# Altium schematic sheet Main.SchDoc(Main)
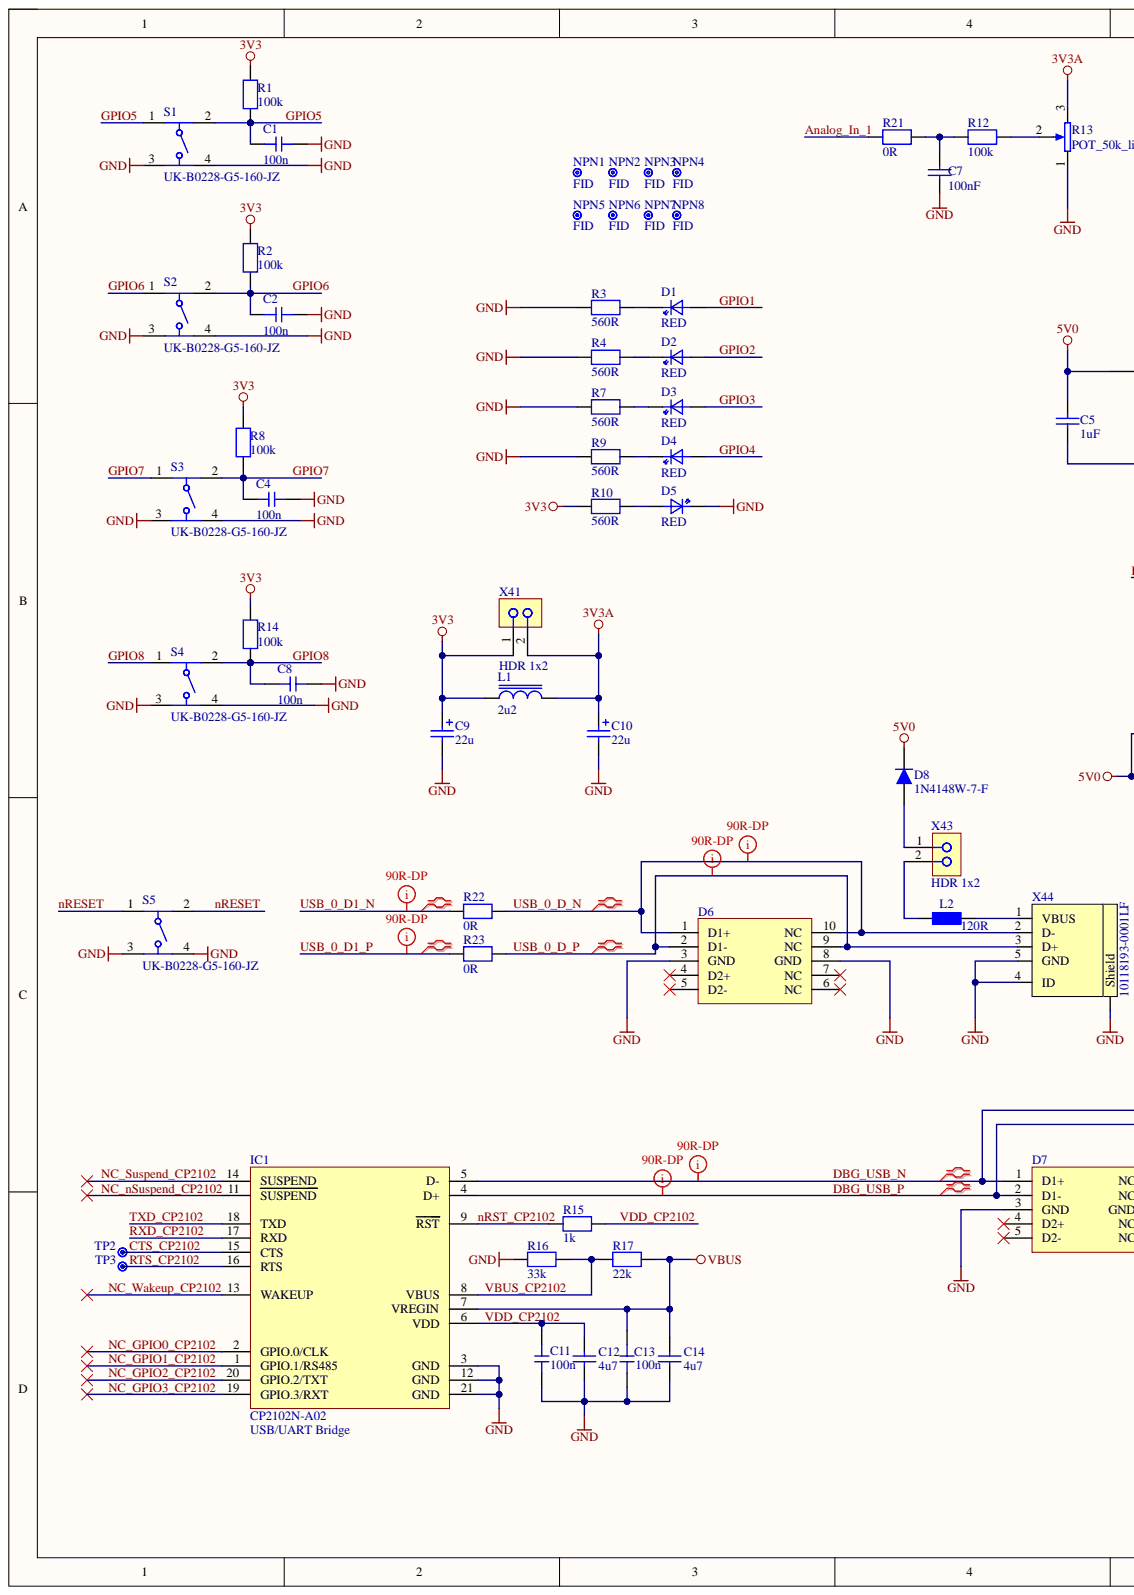
[diagram p0: Main.SchDoc, left]
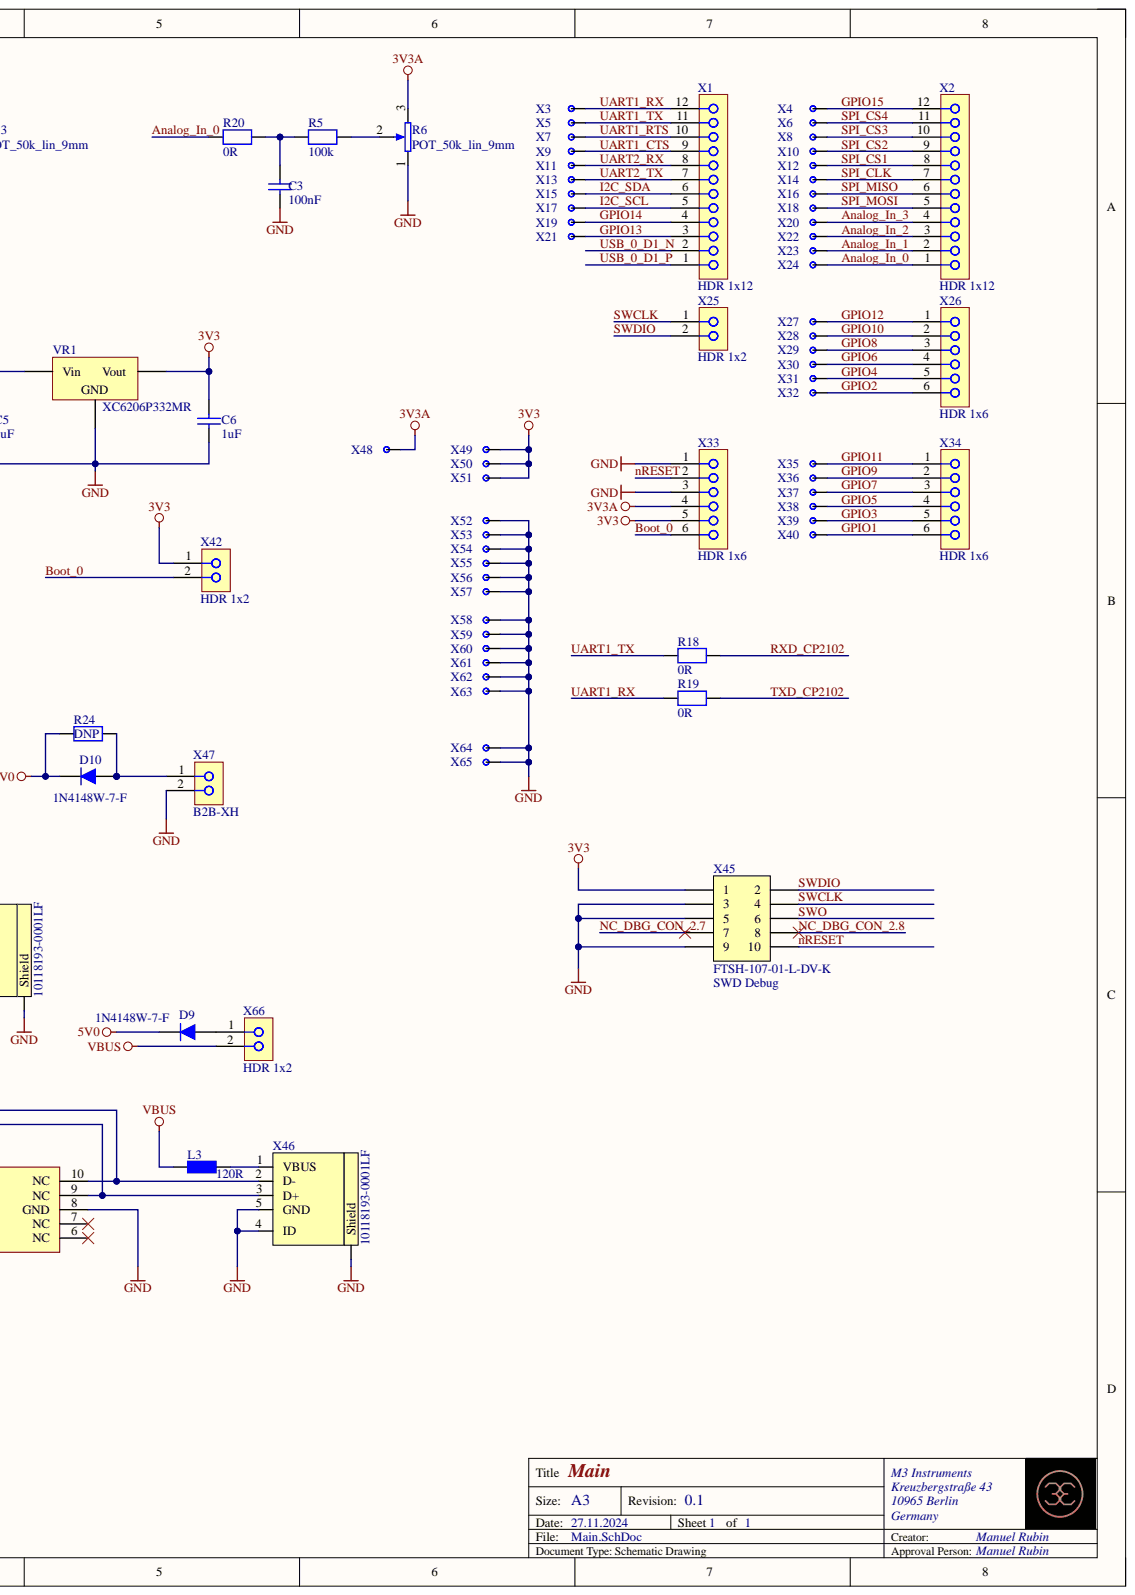
[diagram p1: Main.SchDoc, right]

## Parts
C1  100n  [CAPC1608X95N]
C2  100n  [CAPC1608X95N]
C3  100nF  [CAPC1608X95N]
C4  100n  [CAPC1608X95N]
C5  1uF  [CAPC2012X145N]
C6  1uF  [CAPC2012X145N]
C7  100nF  [CAPC1608X95N]
C8  100n  [CAPC1608X95N]
C9  22u  [CAPAE4643X54M]
C10  22u  [CAPAE4643X54M]
C11  100n  [CAPC1608X95N]
C12  4u7  [CAPC1608X95N]
C13  100n  [CAPC1608X95N]
C14  4u7  [CAPC1608X95N]
D1  RED  [WL-SMCW_0805]
D2  RED  [WL-SMCW_0805]
D3  RED  [WL-SMCW_0805]
D4  RED  [WL-SMCW_0805]
D5  RED  [WL-SMCW_0805]
D6  (no value)  [DQA R-PUSON-N10]
D7  (no value)  [DQA R-PUSON-N10]
D8  1N4148W-7-F  [SOD-123]
D9  1N4148W-7-F  [SOD-123]
D10  1N4148W-7-F  [SOD-123]
IC1  CP2102N-A02  DevNote: USB/UART Bridge  [SILABS_CP2102N-A02-GQFN20R]
L1  2u2  [INDP5050X39N]
L2  120R  [INDC1608X95AN]
L3  120R  [INDC1608X95AN]
NPN1  FID  [Fiducial_1mm]
NPN2  FID  [Fiducial_1mm]
NPN3  FID  [Fiducial_1mm]
NPN4  FID  [Fiducial_1mm]
NPN5  FID  [Fiducial_1mm]
NPN6  FID  [Fiducial_1mm]
NPN7  FID  [Fiducial_1mm]
NPN8  FID  [Fiducial_1mm]
R1  100k  [RESC2012X70N]
R2  100k  [RESC2012X70N]
R3  560R  [RESC1608X55N]
R4  560R  [RESC1608X55N]
R5  100k  [RESC2012X70N]
R6  POT_50k_lin_9mm  [PTV09]  (value not drawn)
R7  560R  [RESC1608X55N]
R8  100k  [RESC2012X70N]
R9  560R  [RESC1608X55N]
R10  560R  [RESC1608X55N]
R12  100k  [RESC2012X70N]
R13  POT_50k_lin_9mm  [PTV09]  (value not drawn)
R14  100k  [RESC2012X70N]
R15  1k  [RESC1608X55AN]
R16  33k  [RESC1608X55AN]
R17  22k  [RESC1608X55AN]
R18  0R  [RESC1608X55N]
R19  0R  [RESC1608X55N]
R20  0R  [RESC1608X55N]
R21  0R  [RESC1608X55N]
R22  0R  [RESC1608X55N]
R23  0R  [RESC1608X55N]
R24  DNP  [RESC2012X70N]  (no BOM)
S1  UK-B0228-G5-160-JZ  [UK-B0228-G5-160-JZ]
S2  UK-B0228-G5-160-JZ  [UK-B0228-G5-160-JZ]
S3  UK-B0228-G5-160-JZ  [UK-B0228-G5-160-JZ]
S4  UK-B0228-G5-160-JZ  [UK-B0228-G5-160-JZ]
S5  UK-B0228-G5-160-JZ  [UK-B0228-G5-160-JZ]
TP2  TP 0.7mm  [TP_0.7mm]  (value not drawn)  (no BOM)
TP3  TP 0.7mm  [TP_0.7mm]  (value not drawn)  (no BOM)
VR1  XC6206P332MR  [SOT95P280X135-3N_123]
X1  HDR 1x12  [61301211821_NO]
X2  HDR 1x12  [61301211821_NO]
X3  PAD  [PAD_1.1_1.6]  (value not drawn)
X4  PAD  [PAD_1.1_1.6]  (value not drawn)
X5  PAD  [PAD_1.1_1.6]  (value not drawn)
X6  PAD  [PAD_1.1_1.6]  (value not drawn)
X7  PAD  [PAD_1.1_1.6]  (value not drawn)
X8  PAD  [PAD_1.1_1.6]  (value not drawn)
X9  PAD  [PAD_1.1_1.6]  (value not drawn)
X10  PAD  [PAD_1.1_1.6]  (value not drawn)
X11  PAD  [PAD_1.1_1.6]  (value not drawn)
X12  PAD  [PAD_1.1_1.6]  (value not drawn)
X13  PAD  [PAD_1.1_1.6]  (value not drawn)
X14  PAD  [PAD_1.1_1.6]  (value not drawn)
X15  PAD  [PAD_1.1_1.6]  (value not drawn)
X16  PAD  [PAD_1.1_1.6]  (value not drawn)
X17  PAD  [PAD_1.1_1.6]  (value not drawn)
X18  PAD  [PAD_1.1_1.6]  (value not drawn)
X19  PAD  [PAD_1.1_1.6]  (value not drawn)
X20  PAD  [PAD_1.1_1.6]  (value not drawn)
X21  PAD  [PAD_1.1_1.6]  (value not drawn)
X22  PAD  [PAD_1.1_1.6]  (value not drawn)
X23  PAD  [PAD_1.1_1.6]  (value not drawn)
X24  PAD  [PAD_1.1_1.6]  (value not drawn)
X25  HDR 1x2  [61300211821_NO]
X26  HDR 1x6  [61300611821_NO]
X27  PAD  [PAD_1.1_1.6]  (value not drawn)
X28  PAD  [PAD_1.1_1.6]  (value not drawn)
X29  PAD  [PAD_1.1_1.6]  (value not drawn)
X30  PAD  [PAD_1.1_1.6]  (value not drawn)
X31  PAD  [PAD_1.1_1.6]  (value not drawn)
X32  PAD  [PAD_1.1_1.6]  (value not drawn)
X33  HDR 1x6  [61300611821_NO]
X34  HDR 1x6  [61300611821_NO]
X35  PAD  [PAD_1.1_1.6]  (value not drawn)
X36  PAD  [PAD_1.1_1.6]  (value not drawn)
X37  PAD  [PAD_1.1_1.6]  (value not drawn)
X38  PAD  [PAD_1.1_1.6]  (value not drawn)
X39  PAD  [PAD_1.1_1.6]  (value not drawn)
X40  PAD  [PAD_1.1_1.6]  (value not drawn)
X41  HDR 1x2  [CON_HEADER2.54_1x2W]
X42  HDR 1x2  [CON_HEADER2.54_1x2W]
X43  HDR 1x2  [CON_HEADER2.54_1x2W]
X44  10118193-0001LF  [USB-Micro-B-RA-SMD-PiP]
X45  FTSH-107-01-L-DV-K  DevNote: SWD Debug  [HDR_2x5-SMD-1.27mm-K]
X46  10118193-0001LF  [USB-Micro-B-RA-SMD-PiP]
X47  B2B-XH  [JST_B2B-XH-A_(LF)(SN)]
X48  PAD  [PAD_1.1_1.6]  (value not drawn)
X49  PAD  [PAD_1.1_1.6]  (value not drawn)
X50  PAD  [PAD_1.1_1.6]  (value not drawn)
X51  PAD  [PAD_1.1_1.6]  (value not drawn)
X52  PAD  [PAD_1.1_1.6]  (value not drawn)
X53  PAD  [PAD_1.1_1.6]  (value not drawn)
X54  PAD  [PAD_1.1_1.6]  (value not drawn)
X55  PAD  [PAD_1.1_1.6]  (value not drawn)
X56  PAD  [PAD_1.1_1.6]  (value not drawn)
X57  PAD  [PAD_1.1_1.6]  (value not drawn)
X58  PAD  [PAD_1.1_1.6]  (value not drawn)
X59  PAD  [PAD_1.1_1.6]  (value not drawn)
X60  PAD  [PAD_1.1_1.6]  (value not drawn)
X61  PAD  [PAD_1.1_1.6]  (value not drawn)
X62  PAD  [PAD_1.1_1.6]  (value not drawn)
X63  PAD  [PAD_1.1_1.6]  (value not drawn)
X64  PAD  [PAD_1.1_1.6]  (value not drawn)
X65  PAD  [PAD_1.1_1.6]  (value not drawn)
X66  HDR 1x2  [CON_HEADER2.54_1x2W]

## Nets
3V3: C6.1 C9.1 L1.1 R1.2 R2.2 R8.2 R10.1 R14.2 VR1.2 X33.5 X41.1 X42.1 X45.1 X49.1 X50.1 X51.1
3V3A: C10.1 L1.2 R6.3 R13.3 X33.4 X41.2 X48.1
5V0: C5.1 D8.2 D9.2 D10.2 R24.1 VR1.3
GND: C1.2 C2.2 C3.2 C4.2 C5.2 C6.2 C7.2 C8.2 C9.2 C10.2 C11.1 C12.1 C13.1 C14.1 D5.K D6.3(GND) D6.8(GND) D7.3(GND) D7.8(GND) IC1.3(GND) IC1.12(GND) IC1.21(GND) R3.1 R4.1 R6.1 R7.1 R9.1 R13.1 R16.2 S1.3 S1.4 S2.3 S2.4 S3.3 S3.4 S4.3 S4.4 S5.3 S5.4 VR1.1 X33.1 X33.3 X44.4(ID) X44.5(GND) X44.6(Shield) X45.3 X45.5 X45.9 X46.4(ID) X46.5(GND) X46.6(Shield) X47.2 X52.1 X53.1 X54.1 X55.1 X56.1 X57.1 X58.1 X59.1 X60.1 X61.1 X62.1 X63.1 X64.1 X65.1
VBUS: C13.2 C14.2 IC1.7(VREGIN) L3.1 R17.1 X66.2
Analog_In_0: R20.2 X2.1 X24.1
Analog_In_1: R21.2 X2.2 X23.1
Analog_In_2: X2.3 X22.1
Analog_In_3: X2.4 X20.1
Boot_0: X33.6 X42.2
CTS_CP2102: IC1.15(CTS) TP2.1
DBG_USB_N: D7.1(D1+) D7.10(NC) IC1.5(D-) X46.2(D-)
DBG_USB_P: D7.2(D1-) D7.9(NC) IC1.4(D+) X46.3(D+)
GPIO1: D1.A X34.6 X40.1
GPIO2: D2.A X26.6 X32.1
GPIO3: D3.A X34.5 X39.1
GPIO4: D4.A X26.5 X31.1
GPIO5: C1.1 R1.1 S1.1 S1.2 X34.4 X38.1
GPIO6: C2.1 R2.1 S2.1 S2.2 X26.4 X30.1
GPIO7: C4.1 R8.1 S3.1 S3.2 X34.3 X37.1
GPIO8: C8.1 R14.1 S4.1 S4.2 X26.3 X29.1
GPIO9: X34.2 X36.1
GPIO10: X26.2 X28.1
GPIO11: X34.1 X35.1
GPIO12: X26.1 X27.1
GPIO13: X1.3 X21.1
GPIO14: X1.4 X19.1
GPIO15: X2.12 X4.1
I2C_SCL: X1.5 X17.1
I2C_SDA: X1.6 X15.1
NC_DBG_CON_2.7: X45.7
NC_DBG_CON_2.8: X45.8
NC_GPIO0_CP2102: IC1.2(GPIO.0/CLK)
NC_GPIO1_CP2102: IC1.1(GPIO.1/RS485)
NC_GPIO2_CP2102: IC1.20(GPIO.2/TXT)
NC_GPIO3_CP2102: IC1.19(GPIO.3/RXT)
NC_nSuspend_CP2102: IC1.11(SUSPEND)
NC_Suspend_CP2102: IC1.14(SUSPEND)
NC_Wakeup_CP2102: IC1.13(WAKEUP)
nRESET: S5.1 S5.2 X33.2 X45.10
nRST_CP2102: IC1.9(RST) R15.2
RTS_CP2102: IC1.16(RTS) TP3.1
RXD_CP2102: IC1.17(RXD) R18.1
SPI_CLK: X2.7 X14.1
SPI_CS1: X2.8 X12.1
SPI_CS2: X2.9 X10.1
SPI_CS3: X2.10 X8.1
SPI_CS4: X2.11 X6.1
SPI_MISO: X2.6 X16.1
SPI_MOSI: X2.5 X18.1
SWCLK: X25.1 X45.4
SWDIO: X25.2 X45.2
SWO: X45.6
TXD_CP2102: IC1.18(TXD) R19.1
UART1_CTS: X1.9 X9.1
UART1_RTS: X1.10 X7.1
UART1_RX: R19.2 X1.12 X3.1
UART1_TX: R18.2 X1.11 X5.1
UART2_RX: X1.8 X11.1
UART2_TX: X1.7 X13.1
USB_0_D1_N: R22.2 X1.2
USB_0_D1_P: R23.2 X1.1
USB_0_D_N: D6.1(D1+) D6.10(NC) R22.1 X44.2(D-)
USB_0_D_P: D6.2(D1-) D6.9(NC) R23.1 X44.3(D+)
VBUS_CP2102: IC1.8(VBUS) R16.1 R17.2
VDD_CP2102: C11.2 C12.2 IC1.6(VDD) R15.1
NetC3_1: C3.1 R5.1 R20.1
NetC7_1: C7.1 R12.1 R21.1
NetD1_K: D1.K R3.2
NetD2_K: D2.K R4.2
NetD3_K: D3.K R7.2
NetD4_K: D4.K R9.2
NetD5_A: D5.A R10.2
NetD8_1: D8.1 X43.1
NetD9_1: D9.1 X66.1
NetD10_1: D10.1 R24.2 X47.1
NetL2_1: L2.1 X43.2
NetL2_2: L2.2 X44.1(VBUS)
NetL3_2: L3.2 X46.1(VBUS)
NetR5_2: R5.2 R6.2
NetR12_2: R12.2 R13.2

Not connected: D6 4(D2+) 5(D2-) 6(NC) 7(NC); D7 4(D2+) 5(D2-) 6(NC) 7(NC)

## Library data of the parts
C1 C2 C4 C8 C11 C13 = C_100n_50V_0603: 100n_50V; Manufacturer: AVX; Manufacturer Part Number: 06031C104KAT2A; Alternatives allowed: YES; Notes: X7R, 0603; Tolerance: +-10%; Value: 100nF; Voltage Rating: 50V; Component Kind: Standard; JLC: C14663; Library Name: Raindancer.SCHLIB
C3 C7 = CAP_100nF_0603: Multilayer Ceramic Capacitors MLCC - SMD/SMT, 100nF; Manufacturer: YAGEO; Manufacturer Part Number: CC0603KRX7R9BB104; Alternatives allowed: yes; Tolerance: 10%; Voltage Rating: 50VDC; Component Kind: Standard; JLC: C14663; Library Name: Caps.SchLib
C5 C6 = CAP_1uF_0805: Multilayer Ceramic Capacitors MLCC - SMD/SMT, 1uF; Manufacturer: Samsung Electro-Mechanics; Manufacturer Part Number: CL21B105KBFNNNE; Alternatives allowed: yes; Tolerance: 10%; Voltage Rating: 50V; Component Kind: Standard; JLC: C28323; Library Name: Caps.SchLib
C9 C10 = CAP_22u: Aluminium Electrolytic Capacitors - SMD 22UF 16V HA SMD; Manufacturer: Panasonic; Manufacturer Part Number: EEE-HAC220WAR; Alternatives allowed: NO; Tolerance: 20%; Component Kind: Standard; Library Name: Caps.SchLib; Voltage Rating: 16V
C12 C14 = CAP_4u7_0603: 16V 4.7uF X5R ±10% 0603 Multilayer Ceramic Capacitors MLCC - SMD/SMT ROHS; Manufacturer: Murata Electronics; Manufacturer Part Number: GRM188C81C475KE11D; Alternatives allowed: yes; Voltage Rating: 16VDC; Component Kind: Standard; JLC: C19666; Library Name: Caps.SchLib; Tolerance: 10%
D1 = LED_0805_RED_AK: Standard LEDs - SMD WL-SMCW SMDMono TpVw Waterclr 0805 Red; Manufacturer: Wurth Elektronik; Manufacturer Part Number: 150080RS75000; Alternatives allowed: YES; DevNote: LED1; Voltage Rating: 2.0; Component Kind: Standard; JLC: C84256; Library Name: Diodes.SchLib
D2 = LED_0805_RED_AK: Standard LEDs - SMD WL-SMCW SMDMono TpVw Waterclr 0805 Red; Manufacturer: Wurth Elektronik; Manufacturer Part Number: 150080RS75000; Alternatives allowed: YES; DevNote: LED2; Voltage Rating: 2.0; Component Kind: Standard; JLC: C84256; Library Name: Diodes.SchLib
D3 = LED_0805_RED_AK: Standard LEDs - SMD WL-SMCW SMDMono TpVw Waterclr 0805 Red; Manufacturer: Wurth Elektronik; Manufacturer Part Number: 150080RS75000; Alternatives allowed: YES; DevNote: LED3; Voltage Rating: 2.0; Component Kind: Standard; JLC: C84256; Library Name: Diodes.SchLib
D4 = LED_0805_RED_AK: Standard LEDs - SMD WL-SMCW SMDMono TpVw Waterclr 0805 Red; Manufacturer: Wurth Elektronik; Manufacturer Part Number: 150080RS75000; Alternatives allowed: YES; DevNote: LED4; Voltage Rating: 2.0; Component Kind: Standard; JLC: C84256; Library Name: Diodes.SchLib
D5 = LED_0805_RED_AK: Standard LEDs - SMD WL-SMCW SMDMono TpVw Waterclr 0805 Red; Manufacturer: Wurth Elektronik; Manufacturer Part Number: 150080RS75000; Alternatives allowed: YES; Voltage Rating: 2.0; Component Kind: Standard; JLC: C84256; Library Name: Diodes.SchLib
D6 D7 = TPD4EUSB30DQAR: 2-, 4-Channel ESD Protection for Super-Speed USB 3.0 Interface; Manufacturer: Texas Instruments; Alternatives allowed: NO; Component Kind: Standard; Library Name: Diodes.SchLib; Manufacturer Part Number: TPD4EUSB30DQAR
D8-D10 = 1N4148W-7-F: Diodes - General Purpose, Power, Switching SURFACE MOUNT FAST SWITCHING DIODE 2A; Manufacturer: Diodes Incorporated; Component Kind: Standard; JLC: C81598; Library Name: Diodes.SchLib; Manufacturer Part Number: 1N4148W-7-F; Package: SOD-123
IC1 = IC_CP2102N-A02-QFN20: USB Interface IC USBXpress - USB to UART Bridge QFN28; Manufacturer: Silicon Labs; Manufacturer Part Number: CP2102N-A02-GQFN20R; Alternatives allowed: NO; Component Kind: Standard; ComponentLink1Description: Datasheet; ComponentLink2Description: Supplier link; JLC: C969913; Library Name: Raindancer.SCHLIB
L1 = IND_2u2_3.8A: Fixed Inductors 2.2uH 3.8A; Manufacturer: Bourns; Manufacturer Part Number: SRN5040TA-2R2M; Alternatives allowed: yes; Tolerance: 10%; Voltage Rating: 50V; Component Kind: Standard; JLC: N/A; Library Name: Inductors.SchLib
L2 L3 = FB_600R_0603: Chip Bead Inductors for Power Lines; Manufacturer: Taiyo Yuden; Manufacturer Part Number: FBMH1608HL121-T; Alternatives allowed: YES; Notes: 0603, 1,2A; Component Kind: Standard; Library Name: Inductors.SchLib; Value: 120R
NPN1-NPN8 = Fiducial_1mm: Component Kind: Standard; Library Name: MISC.SchLib
R1 R2 R5 R8 R12 R14 = RES_100k_0805: Thick Film Resistors - SMD 1/8watt 100Kohms 1% 100ppm; Manufacturer: Vishay / Dale; Manufacturer Part Number: CRCW0805100KFKEA; Alternatives allowed: YES; Voltage Rating: 150V; Component Kind: Standard; JLC: C17407; Library Name: Resistors.SchLib; Tolerance: 1%
R3 R4 R7 R9 R10 = RES_560R_0603: Thick Film Resistors - SMD 560 Ohms 125 mW 0603; Manufacturer: ROHM Semicon; Manufacturer Part Number: MCR03ERTF5600; Alternatives allowed: YES; Tolerance: 1%; Voltage Rating: 150V; Component Kind: Standard; JLC: C224731; Library Name: Resistors.SchLib
R6 R13 = POT_50k_lin_9mm: PTV09A-4020F-A203 50k, 20mm; Manufacturer: Bourns; Manufacturer Part Number: PTV09A-5020F-A503; Tolerance: 20%; Component Kind: Standard; Library Name: Resistors.SchLib
R15 = RES_1k_0603: Thick Film Resistors - SMD 1 kOhms 100mW 0603 1%; Manufacturer: Yageo; Manufacturer Part Number: RC0603FR-071KL; Alternatives allowed: YES; Voltage Rating: 75V; Component Kind: Standard; JLC: C21190; Tolerance: 1%; Library Name: Raindancer.SCHLIB
R16 = RES_33k_0603: Thick Film Resistors - SMD 33 kOhms 100 mW 0603 5%; Manufacturer: YAGEO; Manufacturer Part Number: RC0603JR-0733KL; Alternatives allowed: YES; Tolerance: 1%; Voltage Rating: 50V; Component Kind: Standard; JLC: C4216; Library Name: Raindancer.SCHLIB
R17 = RES_22k_0603: Thick Film Resistors - SMD 22kOhms 1/10W 0603 1%; Manufacturer: YAGEO; Manufacturer Part Number: RC0603FR-7W22KL; Alternatives allowed: YES; Voltage Rating: 75V; Component Kind: Standard; JLC: C31850; Tolerance: 1%; Library Name: Raindancer.SCHLIB
R18-R23 = RES_0R_0603: Thick Film Resistors - SMD 1/10watt ZEROohm Jumper; Manufacturer: Vishay; Manufacturer Part Number: CRCW06030000Z0EA; Alternatives allowed: YES; Tolerance: 1%; Voltage Rating: 75V; Component Kind: Standard; JLC: C21189; Library Name: Resistors.SchLib
R24 = RES_DNF_0805: Resistor SMD 0805 - not populated; Library Name: Resistors.SchLib; Notes: Not populated
S1 = SW_UK-B0228-G5-160-JZ: No NO Gull Wing 50mA 6mm 100MΩ 100000 12V 160gf@±50gf -20℃~+70℃ 6mm 5mm Black Round Button Brick nogging SPST SMD Tactile Switches ROHS; Manufacturer: USAKRO; DevNote: BTN1; Component Kind: Standard; JLC: C620316; Library Name: Switches.SchLib; Manufacturer Part Number: UK-B0228-G5-160-JZ
S2 = SW_UK-B0228-G5-160-JZ: No NO Gull Wing 50mA 6mm 100MΩ 100000 12V 160gf@±50gf -20℃~+70℃ 6mm 5mm Black Round Button Brick nogging SPST SMD Tactile Switches ROHS; Manufacturer: USAKRO; DevNote: BTN2; Component Kind: Standard; JLC: C620316; Library Name: Switches.SchLib; Manufacturer Part Number: UK-B0228-G5-160-JZ
S3 = SW_UK-B0228-G5-160-JZ: No NO Gull Wing 50mA 6mm 100MΩ 100000 12V 160gf@±50gf -20℃~+70℃ 6mm 5mm Black Round Button Brick nogging SPST SMD Tactile Switches ROHS; Manufacturer: USAKRO; DevNote: BTN3; Component Kind: Standard; JLC: C620316; Library Name: Switches.SchLib; Manufacturer Part Number: UK-B0228-G5-160-JZ
S4 = SW_UK-B0228-G5-160-JZ: No NO Gull Wing 50mA 6mm 100MΩ 100000 12V 160gf@±50gf -20℃~+70℃ 6mm 5mm Black Round Button Brick nogging SPST SMD Tactile Switches ROHS; Manufacturer: USAKRO; DevNote: BTN4; Component Kind: Standard; JLC: C620316; Library Name: Switches.SchLib; Manufacturer Part Number: UK-B0228-G5-160-JZ
S5 = SW_UK-B0228-G5-160-JZ: No NO Gull Wing 50mA 6mm 100MΩ 100000 12V 160gf@±50gf -20℃~+70℃ 6mm 5mm Black Round Button Brick nogging SPST SMD Tactile Switches ROHS; Manufacturer: USAKRO; DevNote: Reset; Component Kind: Standard; JLC: C620316; Library Name: Switches.SchLib; Manufacturer Part Number: UK-B0228-G5-160-JZ
TP2 TP3 = MISC_Test Pad 0.7mm: Test Pad surface mount; Alternatives allowed: NO; Notes: Test Pad Only; Manufacturer: N/A; Manufacturer Part Number: N/A; Library Name: Raindancer.SCHLIB
VR1 = IC_XC6206P332MR: LOW DROPOUT REGULATORS(LDO) POSITIVE FIXED 680MV @ 100MA 6V 3.3V 200MA SOT-23-3L ROHS; Manufacturer: Torex Semicon; Component Kind: Standard; JLC: C5446; Library Name: ICs.SchLib; Manufacturer Part Number: XC6206P332MR; Package: SOT-23
X1 = CON_HEADER_1X12_FEMALE_NO: Board-to-Board-Steckverbinder, 2.54 mm, 12 Kontakt(e), Stiftleiste 1-Reihig; Manufacturer: WE; Manufacturer Part Number: 61301211821; Alternatives allowed: YES; DevNote: Board Connector; Component Kind: Standard; Library Name: Connectors.SchLib
X2 = CON_HEADER_1X12_FEMALE_NO: Board-to-Board-Steckverbinder, 2.54 mm, 12 Kontakt(e), Stiftleiste 1-Reihig; Manufacturer: WE; Manufacturer Part Number: 61301211821; Alternatives allowed: YES; Component Kind: Standard; Library Name: Connectors.SchLib
X3-X24 X27-X32 X35-X40 X48-X65 = PAD_2.54: Component Kind: Net Tie; Library Name: MISC.SchLib
X25 = CON_HEADER_1X2_FEMALE_NO: Board-to-Board-Steckverbinder, 2.54 mm, 2 Kontakt(e), Stiftleiste 1-Reihig; Manufacturer: WE; Manufacturer Part Number: 61300211821; Alternatives allowed: YES; Component Kind: Standard; Library Name: Connectors.SchLib
X26 X33 X34 = CON_HEADER_1X6_FEMALE_NO: Board-to-Board-Steckverbinder, 2.54 mm, 6 Kontakt(e), Stiftleiste 1-Reihig; Manufacturer: WE; Manufacturer Part Number: 61300611821; Alternatives allowed: YES; Component Kind: Standard; Library Name: Connectors.SchLib
X41 = CON_HEADER_1X2: Board-to-Board-Steckverbinder, 2.54 mm, 2 Kontakt(e), Stiftleiste 1-Reihig; Manufacturer: Samtec; Manufacturer Part Number: TSW-102-07-T-S; Alternatives allowed: YES; DevNote: Filter Bypass; Component Kind: Standard; Library Name: Connectors.SchLib
X42 = CON_HEADER_1X2: Board-to-Board-Steckverbinder, 2.54 mm, 2 Kontakt(e), Stiftleiste 1-Reihig; Manufacturer: Samtec; Manufacturer Part Number: TSW-102-07-T-S; Alternatives allowed: YES; DevNote: Boot0; Component Kind: Standard; Library Name: Connectors.SchLib
X43 = CON_HEADER_1X2: Board-to-Board-Steckverbinder, 2.54 mm, 2 Kontakt(e), Stiftleiste 1-Reihig; Manufacturer: Samtec; Manufacturer Part Number: TSW-102-07-T-S; Alternatives allowed: YES; DevNote: VBusSel2; Component Kind: Standard; Library Name: Connectors.SchLib
X44 = CON_MiniUSB-AB-SMT: MicroUSB-B-SMT; Manufacturer: Amphenol ICC (FCI); DevNote: USB-MCU; Component Kind: Standard; ComponentLink1Description: Datasheet; ComponentLink2Description: Supplier link; Library Name: Connectors.SchLib; Manufacturer Part Number: 10118193-0001LF
X45 = CON_2x5_SMD_1.27mm: Pin Header 2x5, 1.27mm (50mil), SMD, Keying Shroud; Manufacturer: Samtec; Manufacturer Part Number: FTSH-105-01-L-DV-K; Component Kind: Standard; ComponentLink1Description: Datasheet; ComponentLink2Description: Supplier link; Value: Pin Header 2x5, 1.27mm; Library Name: Raindancer.SCHLIB
X46 = CON_MiniUSB-AB-SMT: MicroUSB-B-SMT; Manufacturer: Amphenol ICC (FCI); DevNote: USB-Debug; Component Kind: Standard; ComponentLink1Description: Datasheet; ComponentLink2Description: Supplier link; Library Name: Connectors.SchLib; Manufacturer Part Number: 10118193-0001LF
X47 = CON_JST_B2B-XH: Connector Header Through Hole 2 position 0.098" (2.50mm); Manufacturer: JST; Manufacturer Part Number: B2B-XH-A (LF)(SN); Alternatives allowed: YES; DevNote: Extern-PWR; Component Kind: Standard; JLC: C158012; Library Name: Connectors.SchLib
X66 = CON_HEADER_1X2: Board-to-Board-Steckverbinder, 2.54 mm, 2 Kontakt(e), Stiftleiste 1-Reihig; Manufacturer: Samtec; Manufacturer Part Number: TSW-102-07-T-S; Alternatives allowed: YES; DevNote: VBusSel1; Component Kind: Standard; Library Name: Connectors.SchLib
